# Altium schematic sheet Sheet1.SchDoc(Sheet1)
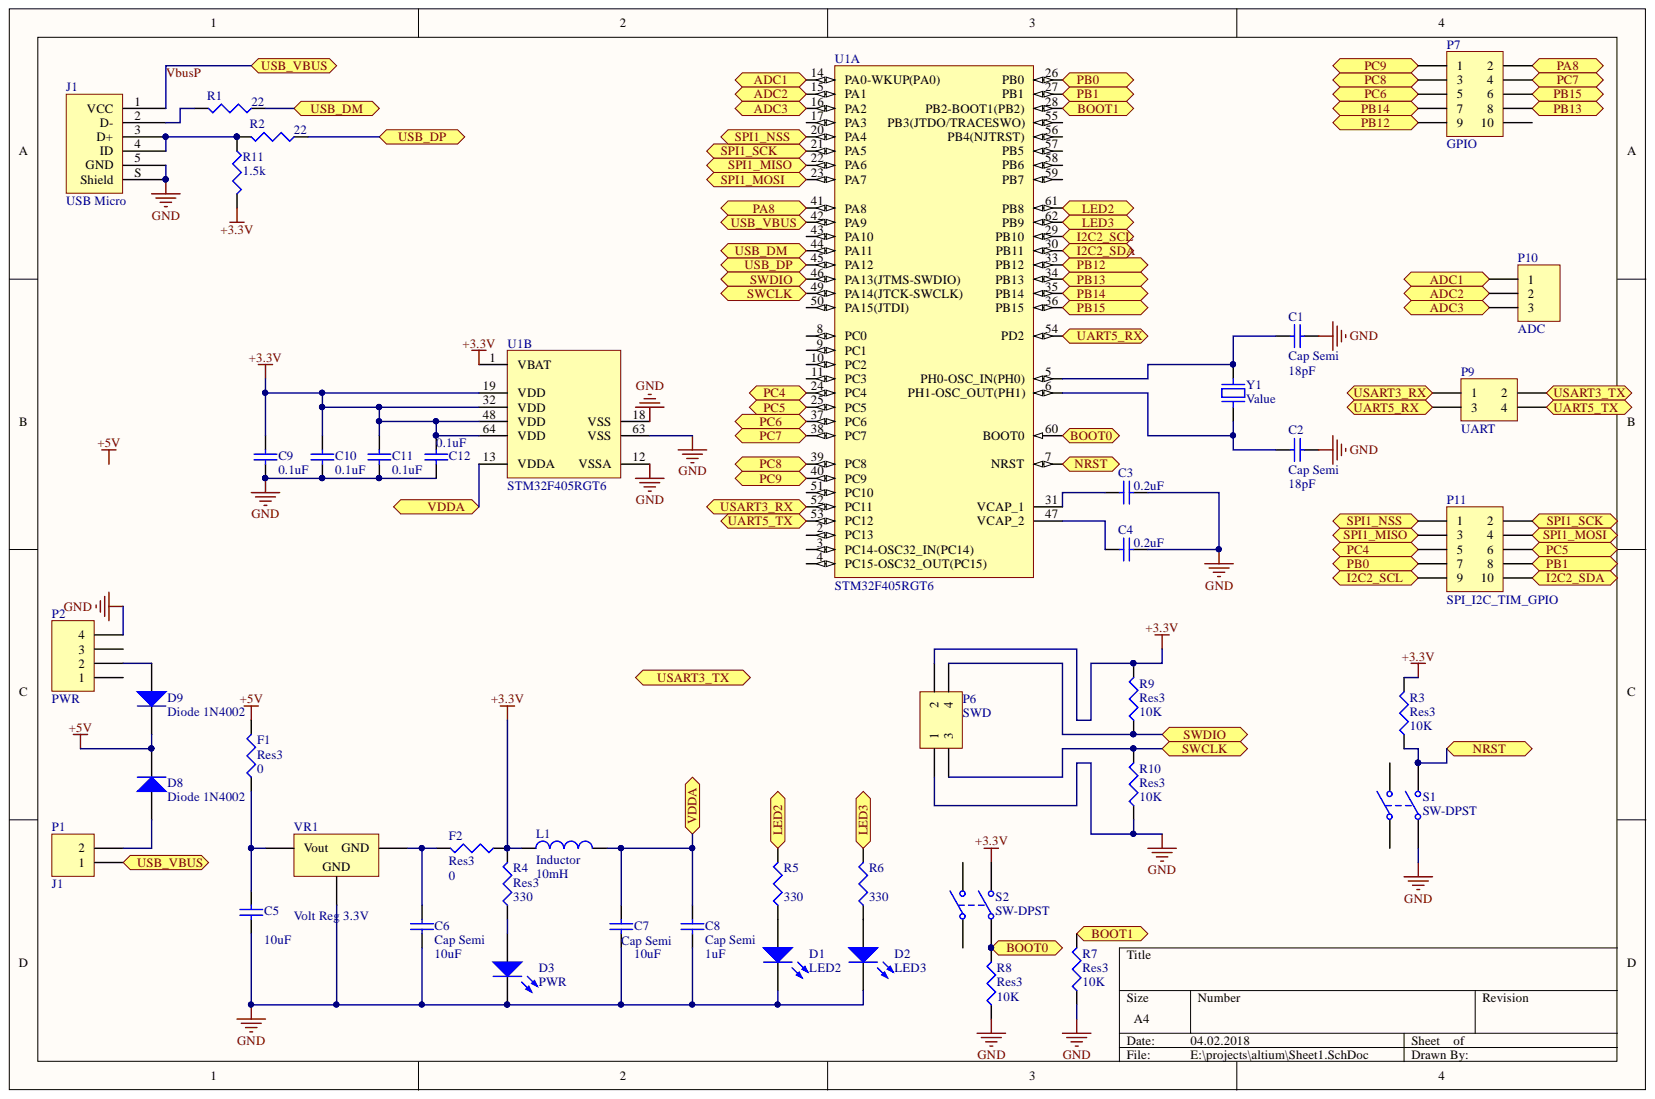
[diagram p0: Sheet1.SchDoc]

## Parts
C1  Cap Semi  value 18pF  [C1206]
C2  Cap Semi  value 18pF  [C1206]
C3  value 0.2uF  [C1206]  (hidden comment: Cap Semi)
C4  value 0.2uF  [C1206]  (hidden comment: Cap Semi)
C5  value 10uF  [C1206]
C6  Cap Semi  value 10uF  [C1206]
C7  Cap Semi  value 10uF  [C1206]
C8  Cap Semi  value 1uF  [C1206]
C9  value 0.1uF  [C0805]  (hidden comment: Cap Semi)
C10  value 0.1uF  [C0805]  (hidden comment: Cap Semi)
C11  value 0.1uF  [C0805]  (hidden comment: Cap Semi)
C12  value 0.1uF  [C0805]  (hidden comment: Cap Semi)
D1  LED2  [3.2X1.6X1.1]
D2  LED3  [3.2X1.6X1.1]
D3  PWR  [3.2X1.6X1.1]
D8  Diode 1N4002  [LED 0805/2012]
D9  Diode 1N4002  [LED 0805/2012]
F1  Res3  value 0  [6-0805_N]
F2  Res3  value 0  [6-0805_N]
J1  USB Micro  [FCI USB 10118193-0001LF]
L1  Inductor  value 10mH  [INDC3216]
P1  J1  [HDR1X2]
P2  PWR  [HDR1X4]
P6  SWD  [HDR2X2]
P7  GPIO  [HDR2X5]
P9  UART  [HDR2X2]
P10  ADC  [HDR1X3]
P11  SPI_I2C_TIM_GPIO  [HDR2X5]
R1  value 22  [6-0805_N]  (hidden comment: Res3)
R2  value 22  [6-0805_N]  (hidden comment: Res3)
R3  Res3  value 10K  [6-0805_N]
R4  Res3  value 330  [6-0805_N]
R5  value 330  [6-0805_N]
R6  value 330  [6-0805_N]  (hidden comment: Res3)
R7  Res3  value 10K  [6-0805_N]
R8  Res3  value 10K  [6-0805_N]
R9  Res3  value 10K  [6-0805_N]
R10  Res3  value 10K  [6-0805_N]
R11  value 1.5k  [6-0805_N]  (hidden comment: Res3)
S1  SW-DPST  [DPST-4]
S2  SW-DPST  [DPST-4]
U1  STM32F405RGT6  [STM-LQFP64_V]  (parts A,B)
VR1  Volt Reg 3.3V  [SOT89L]
Y1  Value  [NDK NX8045GB]

## Ports
ADC1, ADC2, ADC3, BOOT0, BOOT1, I2C2_SCL, I2C2_SDA, LED2, LED3, NRST, PA8, PB0, PB1, PB12, PB13, PB14, PB15, PC4, PC5, PC6, PC7, PC8, PC9, SPI1_MISO, SPI1_MOSI, SPI1_NSS, SPI1_SCK, SWCLK, SWDIO, UART5_RX, UART5_TX, USART3_RX, USART3_TX, USB_DM, USB_DP, USB_VBUS, VDDA

## Nets
+3.3V: C9.1 C10.1 C11.1 C12.1 F2.1 L1.1 P6.2 R3.2 R4.2 R9.2 R11.2 S2.3 U1.1(VBAT) U1.19(VDD) U1.32(VDD) U1.48(VDD) U1.64(VDD)
+5V: D8.2 D9.2 F1.2
GND: C1.2 C2.2 C3.2 C4.2 C5.1 C6.1 C7.1 C8.1 C9.2 C10.2 C11.2 C12.2 D1.2 D2.2 D3.2 J1.5(GND) J1.S(Shield) P2.4 P6.1 R7.1 R8.1 R10.1 S1.3 U1.12(VSSA) U1.18(VSS) U1.63(VSS) VR1.1
ADC1: P10.1 U1.14(PA0-WKUP(PA0)) ; port ADC1
ADC2: P10.2 U1.15(PA1) ; port ADC2
ADC3: P10.3 U1.16(PA2) ; port ADC3
BOOT0: R8.2 S2.4 U1.60(BOOT0) ; port BOOT0
BOOT1: R7.2 U1.28(PB2-BOOT1(PB2)) ; port BOOT1
I2C2_SCL: P11.9 U1.29(PB10) ; port I2C2_SCL
I2C2_SDA: P11.10 U1.30(PB11) ; port I2C2_SDA
LED2: R5.2 U1.61(PB8) ; port LED2
LED3: R6.2 U1.62(PB9) ; port LED3
NRST: R3.1 S1.4 U1.7(NRST) ; port NRST
PA8: P7.2 U1.41(PA8) ; port PA8
PB0: P11.7 U1.26(PB0) ; port PB0
PB1: P11.8 U1.27(PB1) ; port PB1
PB12: P7.9 U1.33(PB12) ; port PB12
PB13: P7.8 U1.34(PB13) ; port PB13
PB14: P7.7 U1.35(PB14) ; port PB14
PB15: P7.6 U1.36(PB15) ; port PB15
PC4: P11.5 U1.24(PC4) ; port PC4
PC5: P11.6 U1.25(PC5) ; port PC5
PC6: P7.5 U1.37(PC6) ; port PC6
PC7: P7.4 U1.38(PC7) ; port PC7
PC8: P7.3 U1.39(PC8) ; port PC8
PC9: P7.1 U1.40(PC9) ; port PC9
SPI1_MISO: P11.3 U1.22(PA6) ; port SPI1_MISO
SPI1_MOSI: P11.4 U1.23(PA7) ; port SPI1_MOSI
SPI1_NSS: P11.1 U1.20(PA4) ; port SPI1_NSS
SPI1_SCK: P11.2 U1.21(PA5) ; port SPI1_SCK
SWCLK: P6.3 R10.2 U1.49(PA14(JTCK-SWCLK)) ; port SWCLK
SWDIO: P6.4 R9.1 U1.46(PA13(JTMS-SWDIO)) ; port SWDIO
UART5_RX: P9.3 U1.54(PD2) ; port UART5_RX
UART5_TX: P9.4 U1.53(PC12) ; port UART5_TX
USART3_RX: P9.1 U1.52(PC11) ; port USART3_RX
USART3_TX: P9.2 ; port USART3_TX
USB_DM: R1.1 U1.44(PA11) ; port USB_DM
USB_DP: R2.1 U1.45(PA12) ; port USB_DP
VbusP: J1.1(VCC) P1.1 U1.42(PA9) ; port USB_VBUS
VDDA: C7.2 C8.2 L1.2 U1.13(VDDA) ; port VDDA
NetC1_1: C1.1 U1.5(PH0-OSC_IN(PH0)) Y1.2
NetC2_1: C2.1 U1.6(PH1-OSC_OUT(PH1)) Y1.1
NetC3_1: C3.1 U1.31(VCAP_1)
NetC4_1: C4.1 U1.47(VCAP_2)
NetC5_2: C5.2 F1.1 VR1.3
NetC6_2: C6.2 F2.2 VR1.2
NetD1_1: D1.1 R5.1
NetD2_1: D2.1 R6.1
NetD3_1: D3.1 R4.1
NetD8_1: D8.1 P1.2
NetD9_1: D9.1 P2.2
NetJ1_2: J1.2(D-) R1.2
NetJ1_3: J1.3(D+) J1.4(ID) R2.2 R11.1

Not connected: P2 1 3; P7 10; S1 1 2; S2 1 2; U1 2(PC13) 3(PC14-OSC32_IN(PC14)) 4(PC15-OSC32_OUT(PC15)) 8(PC0) 9(PC1) 10(PC2) 11(PC3) 17(PA3) 43(PA10) 50(PA15(JTDI)) 51(PC10) 55(PB3(JTDO/TRACESWO)) 56(PB4(NJTRST)) 57(PB5) 58(PB6) 59(PB7)

## Library data of the parts
C1-C12 = Cap Semi: Capacitor (Semiconductor SIM Model); Simulation: CAP; Signal Integrity: Cap Semi; Published: 8-Jun-2000; PackageReference: C1206; PackageDescription: Chip Capacitor; Library Name: Miscellaneous Devices.IntLib; LatestRevisionNote: IPC-7351 Footprint Added.; LatestRevisionDate: 29-May-2009; Component Kind: Standard; Publisher: Altium Limited
D1-D3 = LED2: Typical RED, GREEN, YELLOW, AMBER GaAs LED; Simulation: LED2; Published: 8-Jun-2000; PackageVersion: Feb-2002; PackageReference: 3.2X1.6X1.1; PackageDescription: SM LED; 2 Flat Leads; Library Name: Miscellaneous Devices.IntLib; LatestRevisionNote: Re-released for DXP Platform.; LatestRevisionDate: 17-Jul-2002; Component Kind: Standard; Publisher: Altium Limited
D8 D9 = Diode 1N4002: 1 Amp General Purpose Rectifier; Simulation: 1N4002; Signal Integrity: 1N4002; Published: 8-Jun-2000; PackageVersion: Aug-1999; PackageReference: DO-41; PackageDescription: Diode, Axial; Body 5.2 x 2.7 mm (LxDia. max), Lead Dia. 0.86 mm (max); Library Name: Miscellaneous Devices.IntLib; LatestRevisionNote: Re-released for DXP Platform.; LatestRevisionDate: 17-Jul-2002; DatasheetVersion: 1998; ComponentLink2URL: http://www.fairchildsemi.com/ds/1N%2F1N4001.pdf; ComponentLink2Description: Datasheet; ComponentLink1URL: http://www.fairchildsemi.com/; ComponentLink1Description: Manufacturer Link; Component Kind: Standard; Code_JEDEC: DO-204-AL; Publisher: Altium Limited
F1 F2 R1-R11 = Res3: Resistor; Simulation: RESISTOR; Signal Integrity: Res; Published: 8-Jun-2000; PackageReference: J1-0603; PackageDescription: Chip Resistor; Library Name: Miscellaneous Devices.IntLib; LatestRevisionNote: IPC-7351 Footprint Added.; LatestRevisionDate: 29-May-2009; Component Kind: Standard; Publisher: Altium Limited
J1 = USB 2.0 Type B Micro: Library Name: Connectors.SchLib; Component Kind: Standard
L1 = Inductor: Value: 10mH; Code_IEC: 1005; Simulation: INDUCTOR; Signal Integrity: Inductor; Published: 8-Jun-2000; PackageReference: 0402-A; PackageDescription: Chip Inductor; Library Name: Miscellaneous Devices.IntLib; LatestRevisionNote: IPC-7351 Footprint Added.; LatestRevisionDate: 29-May-2009; Description: Inductor; Component Kind: Standard; Code_JEITA: 0402; Publisher: Altium Limited
P1 = Header 2: Header, 2-Pin; Signal Integrity: Connector; Library Name: Miscellaneous Connectors.IntLib; LatestRevisionNote: Re-released for DXP Platform.; LatestRevisionDate: 17-Jul-2002; Component Kind: Standard; Publisher: Altium Limited
P2 = Header 4: Header, 4-Pin; Signal Integrity: Connector; Library Name: Miscellaneous Connectors.IntLib; LatestRevisionNote: Re-released for DXP Platform.; LatestRevisionDate: 17-Jul-2002; Component Kind: Standard; Publisher: Altium Limited
P6 P9 = Header 2X2: Header, 2-Pin, Dual row; Signal Integrity: Connector; Library Name: Miscellaneous Connectors.IntLib; LatestRevisionNote: Re-released for DXP Platform.; LatestRevisionDate: 17-Jul-2002; Component Kind: Standard; Publisher: Altium Limited
P7 P11 = Header 5X2: Header, 5-Pin, Dual row; Signal Integrity: Connector; Library Name: Miscellaneous Connectors.IntLib; LatestRevisionNote: Re-released for DXP Platform.; LatestRevisionDate: 17-Jul-2002; Component Kind: Standard; Publisher: Altium Limited
P10 = Header 3: Header, 3-Pin; Signal Integrity: Connector; Library Name: Miscellaneous Connectors.IntLib; LatestRevisionNote: Re-released for DXP Platform.; LatestRevisionDate: 17-Jul-2002; Component Kind: Standard; Publisher: Altium Limited
S1 S2 = SW-DPST: Double-Pole, Single-Throw Switch; Published: 24-Mar-1999; PackageReference: DPST-4; PackageDescription: Switch; 4 Leads; Library Name: Miscellaneous Devices.IntLib; LatestRevisionNote: Re-released for DXP Platform.; LatestRevisionDate: 17-Jul-2002; Component Kind: Standard; Publisher: Altium Limited
U1 = STM32F405RGT6: ARM Cortex-M4 32-bit MCU+FPU, 210 DMIPS, 1024 kB Flash, 192 kB Internal RAM, 51 I/Os, 64-pin LQFP, -40 to 85 degC, Tray; Manufacturer: STMicroelectronics; Supply Voltage(Vcc) min (V): 1.8; Supply Voltage(Vcc) max (V): 3.6; Operating Frequency(F) (Processor speed) (MHz): 168; Internal RAM Size (kB): 192; I/Os (High Current): 51; FLASH Size (Prog) (kB): 1024; D/A Converter: 2x12-bit; Supplier Part Number 4: 511-STM32F405RGT6; Supplier Part Number 3: 73T2329; Supplier Part Number 2: 2064363; Supplier Part Number 1: 497-11767-ND; Supplier 4: Mouser; Supplier 3: Newark; Supplier 2: Farnell; Supplier 1: Digi-Key; PartNumber: STM32F405RGT6; Packing: Tray; PackageVersion: Rev. 4, 06/2013; PackageReference: LQFP64; PackageDescription: 64-Pin Low Profile Quad Flat Package, 10 x 10 mm Body, 0.5 mm Pitch; Mounting Technology: Surface Mount; Library Name: STMicroelectronics STM32 F4.IntLib; DatasheetVersion: Rev. 4; Core: ARM Cortex-M4; ComponentLink3URL: http://www.st.com/st-web-ui/static/active/en/resource/technical/document/datasheet/DM00037051.pdf; ComponentLink3Description: Package Specification; ComponentLink2URL: http://www.st.com/st-web-ui/static/active/en/resource/technical/document/datasheet/DM00037051.pdf; ComponentLink2Description: Datasheet; ComponentLink1URL: http://www.st.com/web/en/home.html; ComponentLink1Description: Manufacturer URL; Component Kind: Standard
VR1 = Volt Reg: Voltage Regulator; Published: 24-Mar-1999; PackageReference: D2PAK; PackageDescription: 3-Pin Transistor Outline Package 2.54 mm Pitch, 15.1 mm Lead Span; Library Name: Miscellaneous Devices.IntLib; LatestRevisionNote: IPC-7351 Footprint Added.; LatestRevisionDate: 29-May-2009; Component Kind: Standard; Publisher: Altium Limited
Y1 = Abracon Crystal ABLS: SMT Crystal; Library Name: Crystals.SchLib; Component Kind: Standard
